# Altium schematic sheet Signal Synthesis And Decomposition_Project_V2.0.SchDoc
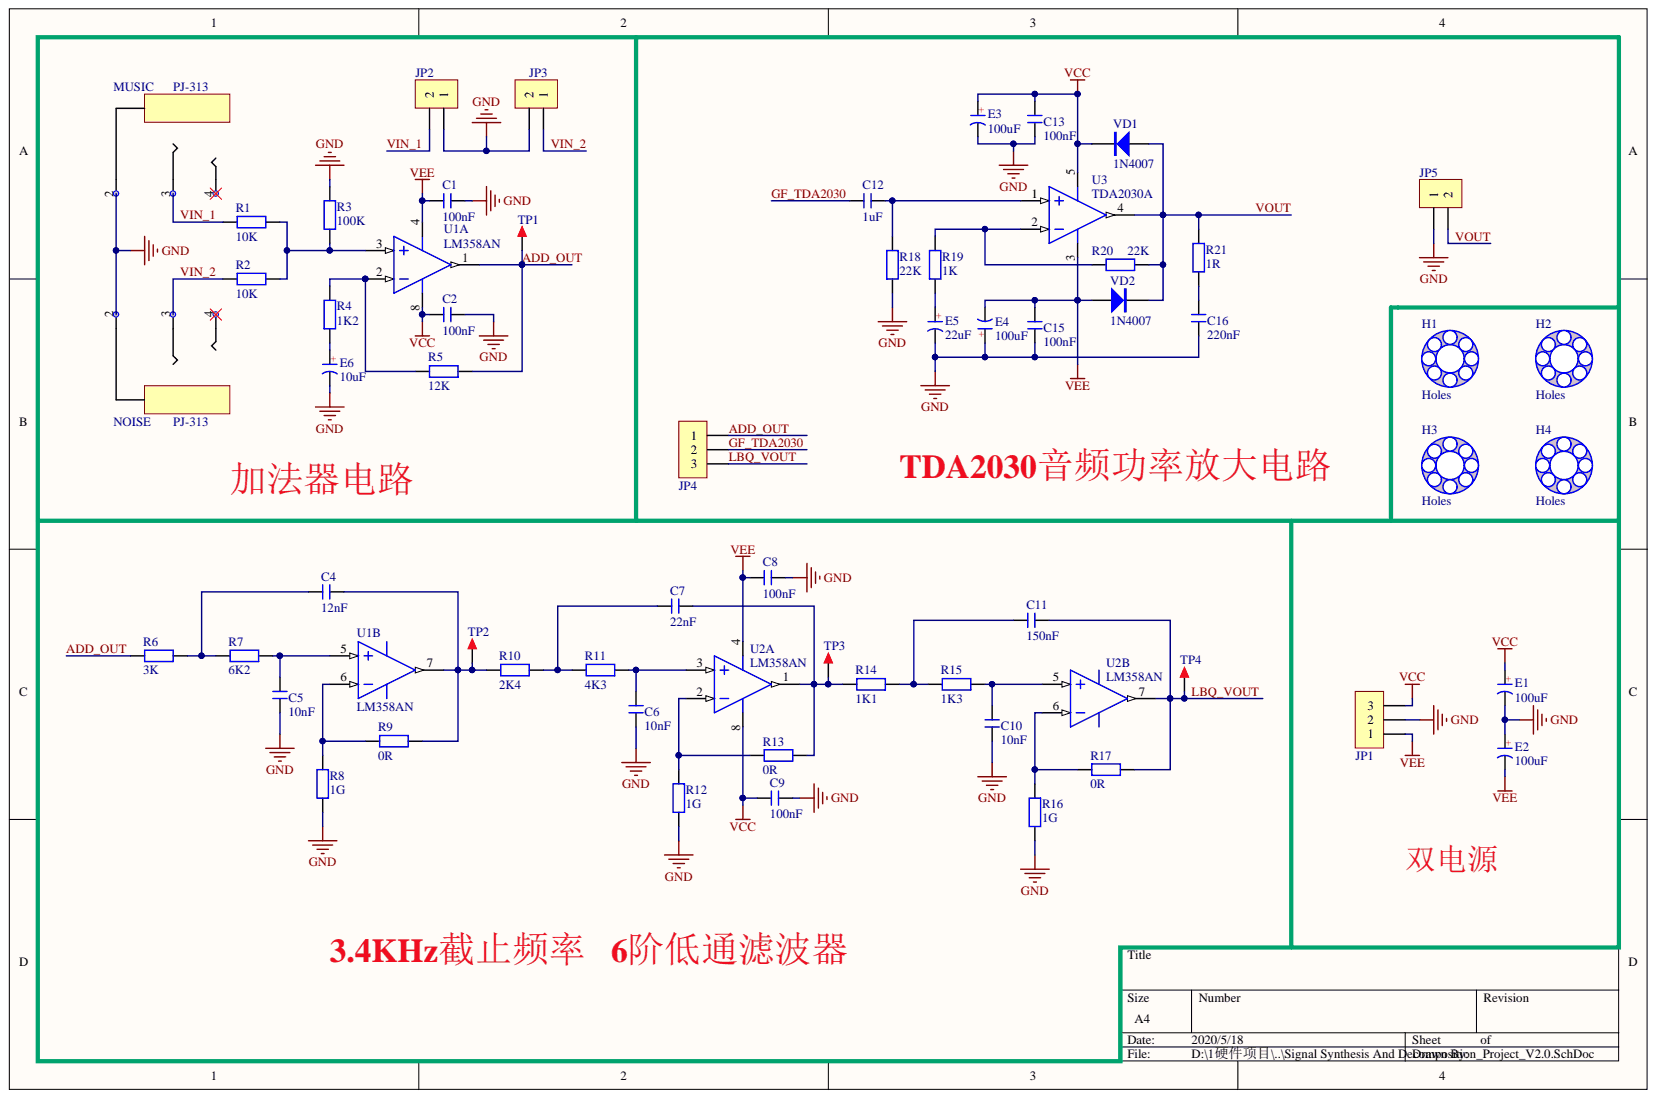
[diagram p0: Signal Synthesis And Decomposition_Project_V2.0.SchDoc]

## Parts
C1  100nF  [C 0805]
C2  100nF  [C 0805]
C4  12nF  [C 0805]
C5  10nF  [C 0805]
C6  10nF  [C 0805]
C7  22nF  [C 0805]
C8  100nF  [C 0805]
C9  100nF  [C 0805]
C10  10nF  [C 0805]
C11  150nF  [C 0805]
C12  1uF  [C 0805]
C13  100nF  [C 0805]
C15  100nF  [C 0805]
C16  220nF  [C 0805]
E1  100uF  [CAP 3.5*8*11.5 - BK]
E2  100uF  [CAP 3.5*8*11.5 - BK]
E3  100uF  [CAP 3.5*8*11.5 - BK]
E4  100uF  [CAP 3.5*8*11.5 - BK]
E5  22uF  [CAP 2.0*5*11 - BK]
E6  10uF  [CAP 2.0*5*11 - BK]
H1  Holes  [定位孔 Φ3 1]
H2  Holes  [定位孔 Φ3 1]
H3  Holes  [定位孔 Φ3 1]
H4  Holes  [定位孔 Φ3 1]
JP1  HDR-1X3  [KF301-3P]  (value not drawn)
JP2  HDR-1X2  [KF301-2P]  (value not drawn)
JP3  HDR-1X2  [KF301-2P]  (value not drawn)
JP4  HDR-1X3  [HDR-1X3]  (value not drawn)
JP5  HDR-1X2  [KF301-2P]  (value not drawn)
MUSIC  PJ-313  [PJ-313-SMD]
NOISE  PJ-313  [PJ-313-SMD]
R1  10K  [R 0805]
R2  10K  [R 0805]
R3  100K  [R 0805]
R4  1K2  [R 0805]
R5  12K  [R 0805]
R6  3K  [R 0805]
R7  6K2  [R 0805]
R8  1G  [R 0805]
R9  0R  [R 0805]
R10  2K4  [R 0805]
R11  4K3  [R 0805]
R12  1G  [R 0805]
R13  0R  [R 0805]
R14  1K1  [R 0805]
R15  1K3  [R 0805]
R16  1G  [R 0805]
R17  0R  [R 0805]
R18  22K  [R 0805]
R19  1K  [R 0805]
R20  22K  [R 0805]
R21  1R  [R 0805]
TP1  TEST TP  [HDR-1X1]  (value not drawn)
TP2  TEST TP  [HDR-1X1]  (value not drawn)
TP3  TEST TP  [HDR-1X1]  (value not drawn)
TP4  TEST TP  [HDR-1X1]  (value not drawn)
U1  LM358AN  [SC_SOIC8]  (parts A,B)
U2  LM358AN  [SC_SOIC8]  (parts A,B)
U3  TDA2030A  [TDA2030A]
VD1  1N4007  [SMA(DO-214AC)_S1]
VD2  1N4007  [SMA(DO-214AC)_S1]

## Nets
GND: C1.1 C2.1 C5.2 C6.2 C8.1 C9.1 C10.2 C13.2 C15.2 C16.2 E1.2 E2.1 E3.2 E4.1 E5.2 E6.2 JP1.2 JP2.1 JP3.2 JP5.1 MUSIC.2 NOISE.2 R3.2 R8.1 R12.1 R16.1 R18.2
VCC: C2.2 C9.2 C13.1 E1.1 E3.1 JP1.3 U1.8 U2.8 U3.5 VD1.2
VEE: C1.2 C8.2 C15.1 E2.2 E4.2 JP1.1 U1.4 U2.4 U3.3 VD2.1
ADD_OUT: JP4.1 R5.2 R6.1 TP1.1 U1.1
GF_TDA2030: C12.2 JP4.2
LBQ_VOUT: C11.1 JP4.3 R17.1 TP4.1 U2.7
VIN_1: JP2.2 MUSIC.3 R1.2
VIN_2: JP3.1 NOISE.3 R2.2
VOUT: JP5.2 R20.2 R21.2 U3.4 VD1.1 VD2.2
NetC4_1: C4.1 R9.1 R10.1 TP2.1 U1.7
NetC4_2: C4.2 R6.2 R7.1
NetC5_1: C5.1 R7.2 U1.5
NetC6_1: C6.1 R11.2 U2.3
NetC7_1: C7.1 R13.1 R14.1 TP3.1 U2.1
NetC7_2: C7.2 R10.2 R11.1
NetC10_1: C10.1 R15.2 U2.5
NetC11_2: C11.2 R14.2 R15.1
NetC12_1: C12.1 R18.1 U3.1
NetC16_1: C16.1 R21.1
NetE5_1: E5.1 R19.2
NetE6_1: E6.1 R4.2
NetR1_1: R1.1 R2.1 R3.1 U1.3
NetR4_1: R4.1 R5.1 U1.2
NetR8_2: R8.2 R9.2 U1.6
NetR12_2: R12.2 R13.2 U2.2
NetR16_2: R16.2 R17.2 U2.6
NetR19_1: R19.1 R20.1 U3.2

Not connected: MUSIC 4; NOISE 4

## Library data of the parts
C1 C2 C4-C13 C15 C16 = C: 无极性贴片电容; 版权归属: 源创客; BOM名称: 无极性贴片电容; Component Kind: Standard; Library Name: 电阻-电容-电感.SchLib; 学习资源: www.ooocke.com
E1-E6 = C-CAP: 直插电解电容; 版权归属: 源创客; BOM名称: 直插电解电容; Component Kind: Standard; Library Name: 电阻-电容-电感.SchLib; 学习资源: www.ooocke.com
H1-H4 = Holes: holes in the corner of the bord; Component Kind: Standard; Library Name: My Footprint.IntLib
JP1 JP4 = Header 3: 3P接插件; 版权归属: 源创客; BOM名称: 3P接插件; Component Kind: Standard; Library Name: 接插件.SchLib; 学习资源: www.ooocke.com
JP2 JP3 JP5 = Header 2: 2P接插件; 版权归属: 源创客; BOM名称: 2P接插件; Component Kind: Standard; Library Name: 接插件.SchLib; 学习资源: www.ooocke.com
R1-R21 = R: 贴片电阻; 版权归属: 源创客; BOM名称: 贴片电阻; Component Kind: Standard; Library Name: 电阻-电容-电感.SchLib; 学习资源: www.ooocke.com
TP1-TP4 = TEST TP: 测试环; 版权归属: 源创客; BOM名称: 测试环; Component Kind: Standard; Library Name: 未分类器件.SchLib; 学习资源: www.ooocke.com
U1 U2 = LM358AN: Low-Power Dual Operational Amplifier; Manufacturer: National Semiconductor; Class: Amplifier; Component Kind: Standard; Library Name: My Footprint.IntLib; Published: 15/04/2002; Simulation: LM358_NSC; SubClass: Operational Amplifier; Publisher: Altium Hobart Technology Centre
VD1 VD2 = 1N4007: 整流二极管; 版权归属: 源创客; BOM名称: 整流二极管; Component Kind: Standard; Library Name: 二极管-整流桥.SchLib; 学习资源: www.ooocke.com
MUSIC NOISE = PJ-313: Component Kind: Standard; Library Name: My Footprint.IntLib
U3 = TDA2030A: Component Kind: Standard; Library Name: My Footprint.IntLib
